# Altium schematic sheet 04_TC4xx_Supply_Clk_Cfg_Dbg.SchDoc
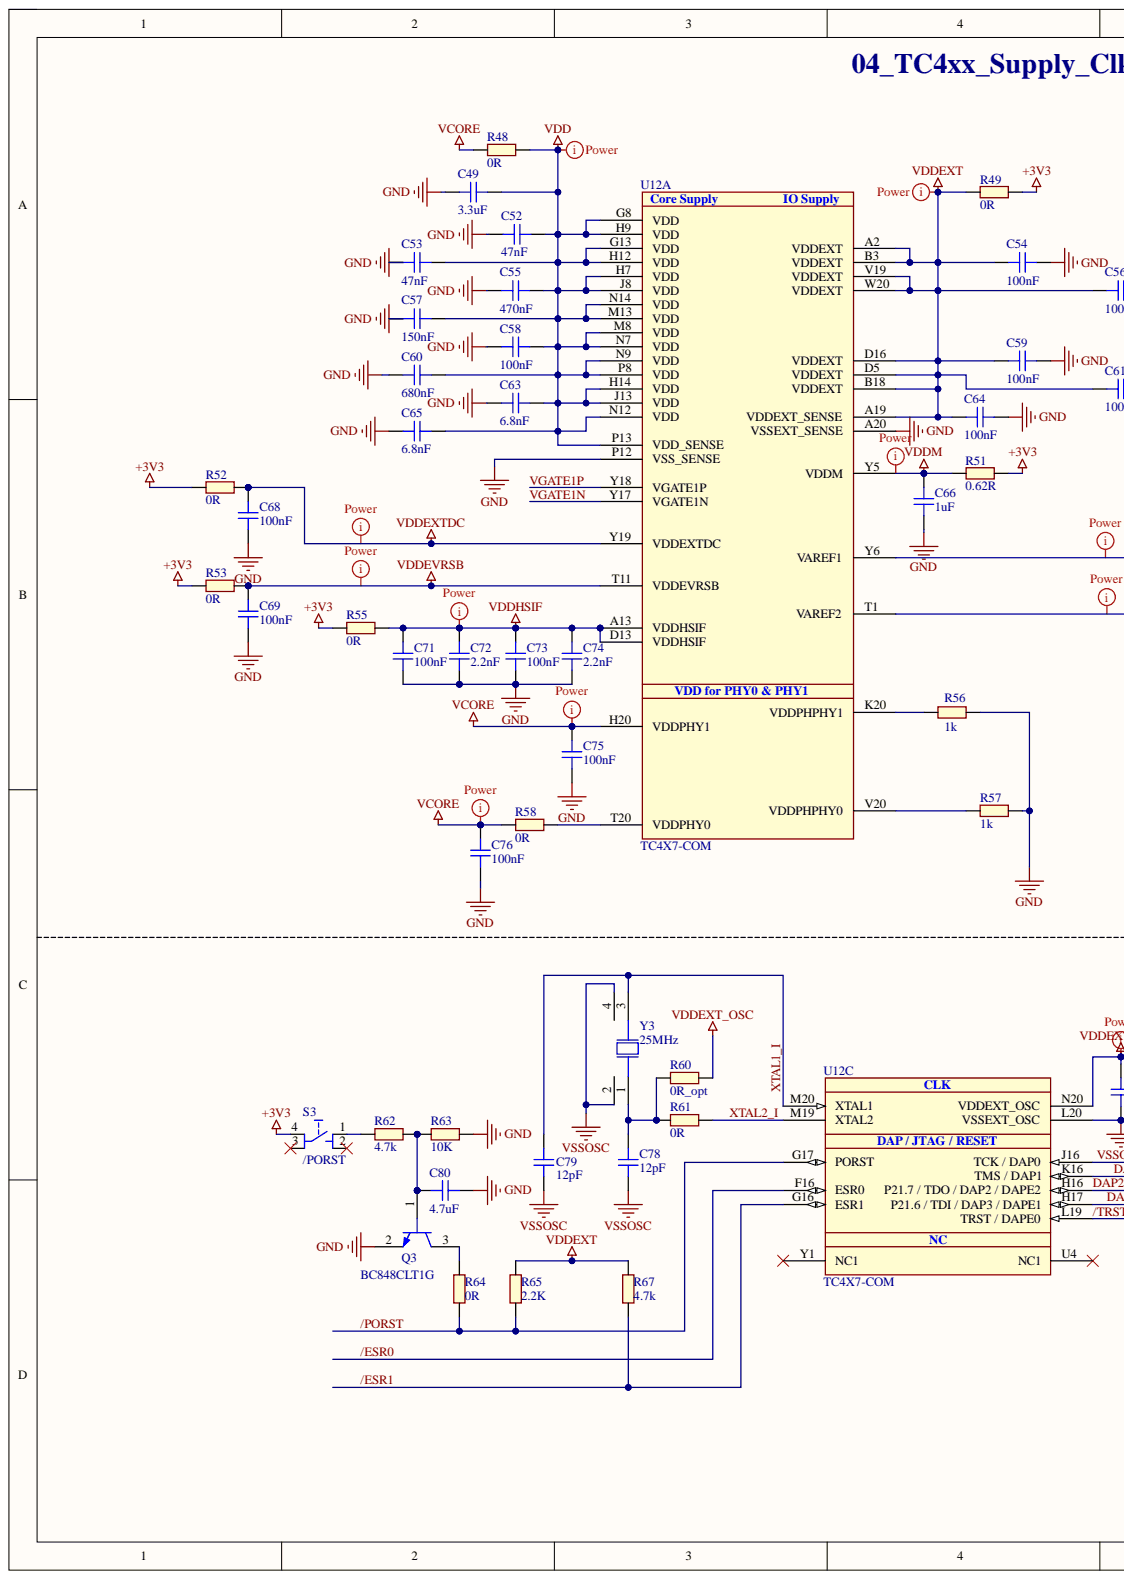
[diagram p0: 04_TC4xx_Supply_Clk_Cfg_Dbg.SchDoc, left]
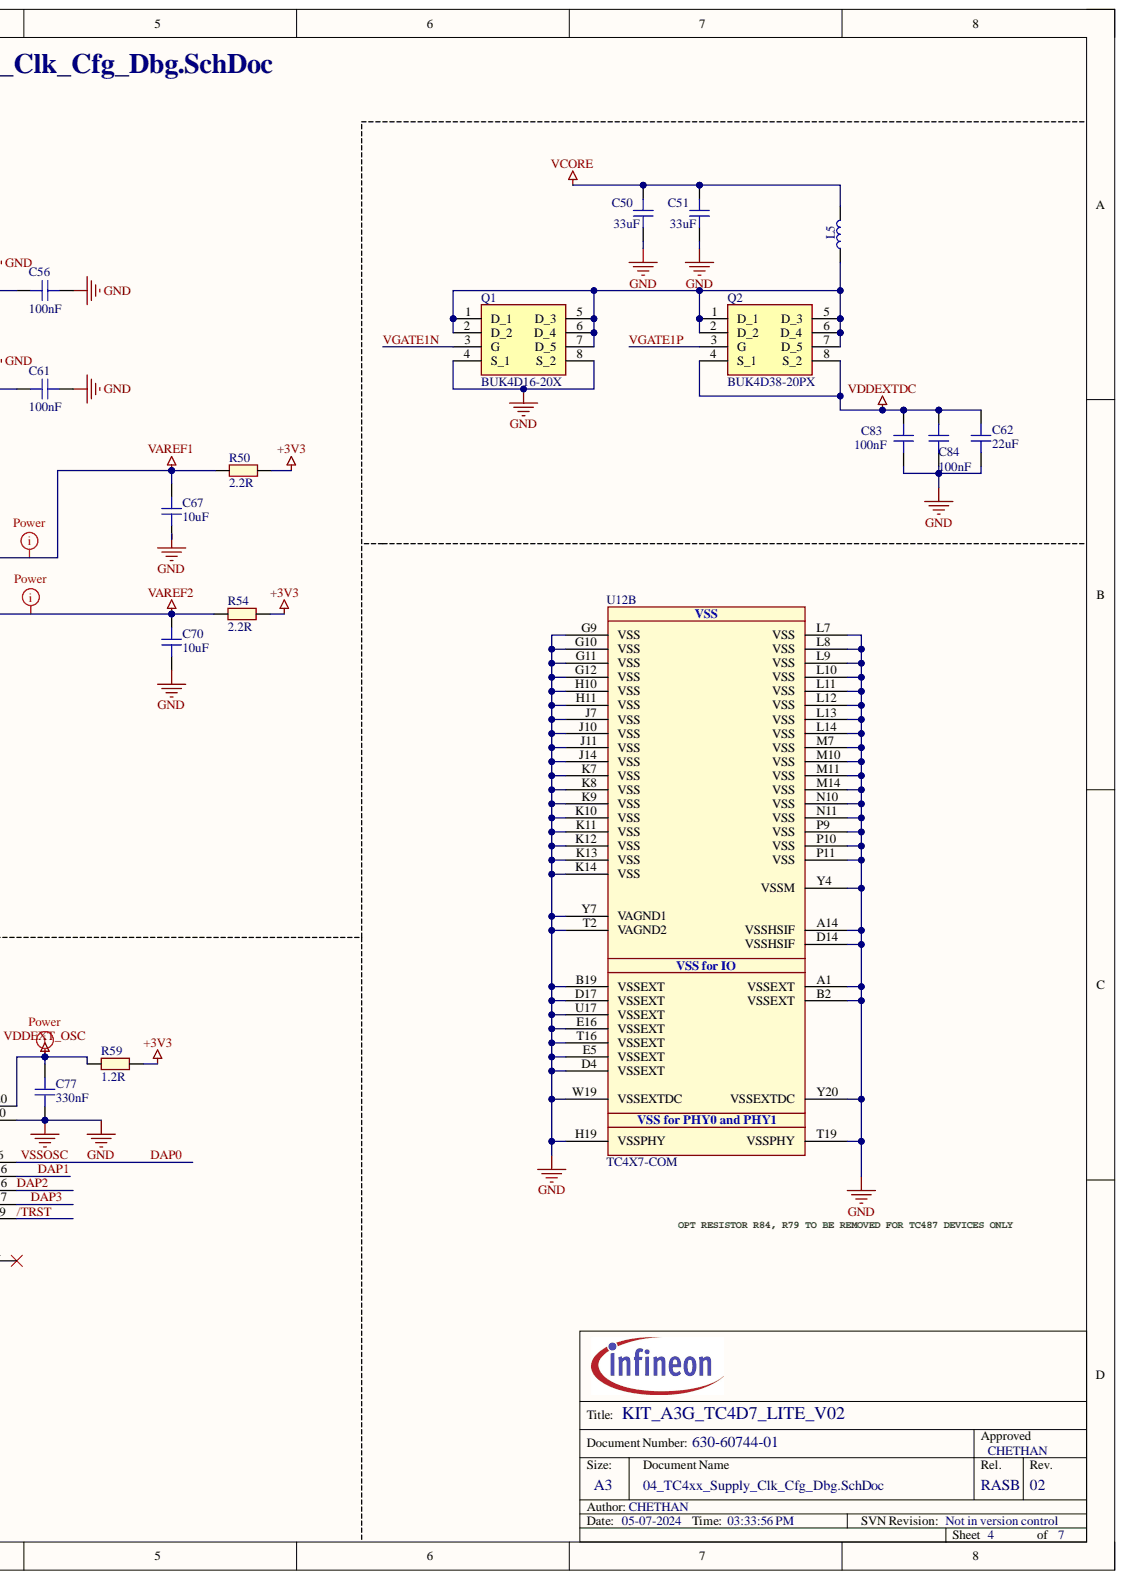
[diagram p1: 04_TC4xx_Supply_Clk_Cfg_Dbg.SchDoc, right]

## Parts
C49  3.3uF  [CAPC1608X90N-3_1]
C50  33uF  [CAPC3225X280N-3]
C51  33uF  [CAPC3225X280N-3]
C52  47nF  [CAPC1005X55N-0]
C53  47nF  [CAPC1005X55N-0]
C54  100nF  [CAPC1005X55N-0]
C55  470nF  [CAPC1005X55N-0]
C56  100nF  [CAPC1005X55N-0]
C57  150nF  [CAPC1005X55N-0]
C58  100nF  [CAPC1005X55N-0]
C59  100nF  [CAPC1005X55N-0]
C60  680nF  [CAPC1005X55N-0]
C61  100nF  [CAPC1005X55N-0]
C62  22uF  [CAPC3225X280N-0]
C63  6.8nF  [CAPC1005X55N-0]
C64  100nF  [CAPC1005X55N-0]
C65  6.8nF  [CAPC1005X55N-0]
C66  1uF  [CAPC1005X55N]
C67  10uF  [CAPC1608X100N]
C68  100nF  [CAPC1005X55N-0]
C69  100nF  [CAPC1005X55N-0]
C70  10uF  [CAPC1608X100N]
C71  100nF  [CAPC1005X55N-0]
C72  2.2nF  [CAPC1005X55N-0]
C73  100nF  [CAPC1005X55N-0]
C74  2.2nF  [CAPC1005X55N-0]
C75  100nF  [CAPC1005X55N-0]
C76  100nF  [CAPC1005X55N-0]
C77  330nF  [CAPC1005X55N-0]
C78  12pF  [CAPC1608X90N]
C79  12pF  [CAPC1608X90N]
C80  4.7uF  [CAPC1005X65N]
C83  100nF  [CAPC1005X55N-0]
C84  100nF  [CAPC1005X55N-0]
L5  XAL7030-222MEC  [XAL7030-222MEC]  (value not drawn)
Q1  BUK4D16-20X  [BUK4D1620X]
Q2  BUK4D38-20PX  [BUK4D3820PX]
Q3  BC848CLT1G  [SOT95P240X110-3N_01]
R48  0R  [RESC3246X60N]
R49  0R  [RESC3225X60N]
R50  2.2R  [RESC1609X50N]
R51  0.62R  [RESC1608X55N-2]
R52  0R  [RESC3225X60N]
R53  0R  [RESC1609X50N]
R54  2.2R  [RESC1609X50N]
R55  0R  [RESC1609X50N]
R56  1k  [RESC1005X40N-1]
R57  1k  [RESC1005X40N-1]
R58  0R  [RESC1609X50N]
R59  1.2R  [RESC1609X50N]
R60  0R_opt  [RESC1609X50N]
R61  0R  [RESC1609X50N]
R62  4.7k  [RESC1005X40N]
R63  10K  [RESC1005X40N]
R64  0R  [RESC1609X50N]
R65  2.2K  [RESC1609X50N]
R67  4.7k  [RESC1609X50N]
S3  /PORST  [FSM2JSMA]
U12  TC4X7-COM  [TC4X7_292BGA]  (parts A,B,C)
Y3  25MHz  [ECS2501233QJESTR]

## Nets
+3V3: R49.1 R50.2 R51.2 R52.2 R53.1 R54.2 R55.1 R59.2 S3.4
GND: C49.1 C50.1 C51.1 C52.1 C53.1 C54.2 C55.1 C56.2 C57.1 C58.1 C59.2 C60.1 C61.2 C62.2 C63.1 C64.2 C65.1 C66.1 C67.1 C68.1 C69.1 C70.1 C71.1 C72.2 C73.2 C74.2 C75.2 C76.2 C77.1 C78.1 C79.1 C80.1 C83.1 C84.1 Q1.4(S_1) Q1.8(S_2) Q3.2 R56.1 R57.2 R63.2 U12.A1(VSSEXT) U12.A14(VSSHSIF) U12.A20(VSSEXT_SENSE) U12.B2(VSSEXT) U12.B19(VSSEXT) U12.D4(VSSEXT) U12.D14(VSSHSIF) U12.D17(VSSEXT) U12.E5(VSSEXT) U12.E16(VSSEXT) U12.G9(VSS) U12.G10(VSS) U12.G11(VSS) U12.G12(VSS) U12.H10(VSS) U12.H11(VSS) U12.H19(VSSPHY) U12.J7(VSS) U12.J10(VSS) U12.J11(VSS) U12.J14(VSS) U12.K7(VSS) U12.K8(VSS) U12.K9(VSS) U12.K10(VSS) U12.K11(VSS) U12.K12(VSS) U12.K13(VSS) U12.K14(VSS) U12.L7(VSS) U12.L8(VSS) U12.L9(VSS) U12.L10(VSS) U12.L11(VSS) U12.L12(VSS) U12.L13(VSS) U12.L14(VSS) U12.L20(VSSEXT_OSC) U12.M7(VSS) U12.M10(VSS) U12.M11(VSS) U12.M14(VSS) U12.N10(VSS) U12.N11(VSS) U12.P9(VSS) U12.P10(VSS) U12.P11(VSS) U12.P12(VSS_SENSE) U12.T2(VAGND2) U12.T16(VSSEXT) U12.T19(VSSPHY) U12.U17(VSSEXT) U12.W19(VSSEXTDC) U12.Y4(VSSM) U12.Y7(VAGND1) U12.Y20(VSSEXTDC) Y3.2 Y3.4
VAREF1: C67.2 R50.1 U12.Y6(VAREF1)
VAREF2: C70.2 R54.1 U12.T1(VAREF2)
VCORE: C50.2 C51.2 C75.1 C76.1 L5.2 R48.1 R58.2 U12.H20(VDDPHY1)
VDD: C49.2 C52.2 C53.2 C55.2 C57.2 C58.2 C60.2 C63.2 C65.2 R48.2 U12.G8(VDD) U12.G13(VDD) U12.H7(VDD) U12.H9(VDD) U12.H12(VDD) U12.H14(VDD) U12.J8(VDD) U12.J13(VDD) U12.M8(VDD) U12.M13(VDD) U12.N7(VDD) U12.N9(VDD) U12.N12(VDD) U12.N14(VDD) U12.P8(VDD) U12.P13(VDD_SENSE)
VDDEVRSB: C69.2 R53.2 U12.T11(VDDEVRSB)
VDDEXT: C54.1 C56.1 C59.1 C61.1 C64.1 R49.2 R65.2 R67.2 U12.A2(VDDEXT) U12.A19(VDDEXT_SENSE) U12.B3(VDDEXT) U12.B18(VDDEXT) U12.D5(VDDEXT) U12.D16(VDDEXT) U12.V19(VDDEXT) U12.W20(VDDEXT)
VDDEXT_OSC: C77.2 R59.1 R60.2 U12.N20(VDDEXT_OSC)
VDDEXTDC: C62.1 C68.2 C83.2 C84.2 Q2.4(S_1) Q2.8(S_2) R52.1 U12.Y19(VDDEXTDC)
VDDHSIF: C71.2 C72.1 C73.1 C74.1 R55.2 U12.A13(VDDHSIF) U12.D13(VDDHSIF)
VDDM: C66.2 R51.1 U12.Y5(VDDM)
/ESR0: U12.F16(ESR0)
/ESR1: R67.1 U12.G16(ESR1)
/PORST: R64.1 R65.1 U12.G17(PORST)
/TRST: U12.L19(TRST_/_DAPE0)
DAP0: U12.J16(TCK_/_DAP0)
DAP1: U12.K16(TMS_/_DAP1)
DAP2: U12.H16
DAP3: U12.H17
VGATE1N: Q1.3(G) U12.Y17(VGATE1N)
VGATE1P: Q2.3(G) U12.Y18(VGATE1P)
XTAL1_I: C79.2 U12.M20(XTAL1) Y3.3
XTAL2_I: R61.2 U12.M19(XTAL2)
NetC78_2: C78.2 R60.1 R61.1 Y3.1
NetC80_2: C80.2 Q3.1 R62.2 R63.1
NetL5_1: L5.1 Q1.1(D_1) Q1.2(D_2) Q1.5(D_3) Q1.6(D_4) Q1.7(D_5) Q2.1(D_1) Q2.2(D_2) Q2.5(D_3) Q2.6(D_4) Q2.7(D_5)
NetQ3_3: Q3.3 R64.2
NetR56_2: R56.2 U12.K20(VDDPHPHY1)
NetR57_1: R57.1 U12.V20(VDDPHPHY0)
NetR58_1: R58.1 U12.T20(VDDPHY0)
NetR62_1: R62.1 S3.1

Not connected: S3 2 3; U12 U4(NC1) Y1(NC1)

## Library data of the parts
C49 = 626196_TB: 3.3 µF ±10% 6.3V Ceramic Capacitor X7S 0603 (1608 Metric); Manufacturer: TDK Corporation; Manufacturer Order Number: C1608X7S0J335K080AC; Multiple Parts: No; Alternate View: Yes; Automotive Qualified: AEC Q200; Combined Parameters: TC:X7S (EIA);Tol.:-10%/+10%;Vdc:6.3V; ComponentStatus: Released; PLMStatus: Active; QuickPick: 3.3uF / 6.3V / 10% / X7S (EIA) / 0603 (1608); Style: Single; Symbol Type: Capacitor; Single; Standard; 2 Pins; Type: Standard; Version Number: V01; Application: Standard; Article Number: 626196_TB; Component Kind: Standard; ComponentLink1Description: Vendor WebSite; ComponentLink1URL: http://www.murata.com/; ComponentLink2Description: Datasheet; Library Name: TriBoard TC4X7 COM.SCHLIB; Package Description: Capacitor,non-polarized,Chip;1.60mm L X 0.80mm W X 0.90mm H; PackageReference: 0603 (1608); Part Type: Capacitor; ROHS Compliant: Y; Second Source allowed: Yes; Supplier 1: Farnell; Supplier 2: DigiKey; Supplier 3: Mouser; Supplier Part Number 2: 445-14250-1-ND; Supplier Part Number 3: 810-C1608X7S0J335K0C; Technology: Ceramic; Temperature max: 125; Temperature min: -55; Temperature Range: Military; Tolerance Neg: 10%; Tolerance Pos: 10%; Value: 3.3uF; Voltage DC (max): 6.3V
C50 C51 = 308865: 33 µF ±20% 10V Ceramic Capacitor X7S 1210 (3225 Metric); Manufacturer: TDK; Manufacturer Component Status: Active; Manufacturer Order Number: CGA6M1X7S1A336M200AC; Alternate View: Yes; Automotive Qualified: No; Legacy DeviceID: CAP01010692; Package Imperial: 1210; Package Metric: 3225; QuickPick: CAP CER 33UF 10V X7S 1210; Style: Single; Symbol Type: Capacitor; Single; Standard; 2 Pins; Type: Standard; Version Number: V01; Voltage DC (max): 16V; Article Number: 308865; Combined Parameters: 33 µF ±20% 10V Ceramic Capacitor X7S 1210 (3225 Metric); Component Kind: Standard; ComponentLink1Description: Vendor WebSite; ComponentLink2Description: Datasheet; Legacy PartNumber: CAP01010692; Multiple Parts: No; Package Description: Capacitor,non-polarized,Chip;3.20mm L X 2.50mm W X 2.80mm H; PackageReference: 1210; Part Type: Capacitor; PLMStatus: Active; ROHS Compliant: Y; Second Source allowed: Yes; Supplier 1: Farnell; Supplier 2: DigiKey; Supplier 3: Mouser; Supplier Part Number 2: 445-CGA6M1X7S1A336M200ACCT-ND; Supplier Part Number 3: 810-CGA6M1X7S1A336M2; Technology: Ceramic; Temperature max: 85; Temperature min: -55; Temperature Range: Military; Tolerance Neg: 20%; Tolerance Pos: 20%; Value: 33uF; Library Name: AURIX_Lite_Kit_V2.0.SCHLIB
  C50: ComponentLink2URL: https://product.tdk.com/system/files/dam/doc/product/capacitor/ceramic/mlcc/catalog/mlcc_commercial_general_en.pdf
  C51: ComponentLink1URL: http://www.kemet.com; ComponentLink2URL: http://webnetprod.muc.infineon.com/PCBPortal_Prod/WorkFlows/AltiumComponent/StandardDocumentation?Versionid=308866
C52 C53 = 287081: 0.047 µF ±10% 25V Ceramic Capacitor X7R 0402 (1005 Metric); Manufacturer: MuRata; Manufacturer Order Number: GCM155R71E473KA55D; Multiple Parts: No; Alternate View: Yes; Automotive Qualified: AEC Q200; Combined Parameters: TC:X7R (EIA);Tol.:-10%/+10%;Vdc:25V; ComponentStatus: Released; Legacy DeviceID: CAP01006489; Package Imperial: 0402; Package Metric: 1005; PLMStatus: Active; QuickPick: 47nF / 25V / 10% / X7R (EIA) / CAPC1005X55N-0; Style: Single; Symbol Type: Capacitor; Single; Standard; 2 Pins; Type: Standard; Version Number: V01; Application: Standard; Article Number: 287081; Component Kind: Standard; ComponentLink1Description: Vendor WebSite; ComponentLink1URL: http://www.murata.com/; ComponentLink2Description: Datasheet; ComponentLink2URL: http://webnetprod.muc.infineon.com/PCBPortal_Prod/WorkFlows/AltiumComponent/StandardDocumentation?Versionid=287082; Legacy PartNumber: CAP01006489; Library Name: TriBoard_TC4X7_COM_V20.SCHLIB; Package Description: Capacitor,non-polarized,Chip;1.00mm L X 0.50mm W X 0.55mm H; PackageReference: CAPC1005X55N-0; Part Type: Capacitor; ROHS Compliant: Y; Second Source allowed: Yes; Supplier 1: Farnell; Supplier 2: DigiKey; Supplier 3: Mouser; Supplier Part Number 2: 490-4760-1-ND; Technology: Ceramic; Temperature max: 125; Temperature min: -55; Temperature Range: Military; Tolerance Neg: 10%; Tolerance Pos: 10%; Value: 47nF; Voltage DC (max): 25V
C54 C56 C59 C61 C64 C71 C83 C84 = 287096: 0.1 µF ±10% 50V Ceramic Capacitor X7R 0402 (1005 Metric); Manufacturer: MuRata; Manufacturer Order Number: GCM155R71H104KE02J; Multiple Parts: No; Alternate View: Yes; Automotive Qualified: AEC Q200; Combined Parameters: TC:X7R (EIA);Tol.:-10%/+10%;Vdc:50V; Legacy DeviceID: CAP01006492; Package Imperial: 0402; Package Metric: 1005; PLMStatus: Active; QuickPick: 100nF / 50V / 10% / X7R (EIA) / CAPC1005X55N-0; Style: Single; Symbol Type: Capacitor; Single; Standard; 2 Pins; Type: Standard; Version Number: V01; Application: Standard; Article Number: 287096; Component Kind: Standard; ComponentLink1Description: Vendor WebSite; ComponentLink1URL: http://www.murata.com/; ComponentLink2Description: Datasheet; ComponentLink2URL: http://webnetprod.muc.infineon.com/PCBPortal_Prod/WorkFlows/AltiumComponent/StandardDocumentation?Versionid=287097; Legacy PartNumber: CAP01006492; Library Name: TriBoard_TC4X7_COM_V20.SCHLIB; Package Description: Capacitor,non-polarized,Chip;1.00mm L X 0.50mm W X 0.55mm H; PackageReference: CAPC1005X55N-0; Part Type: Capacitor; ROHS Compliant: Y; Second Source allowed: Yes; Supplier 1: Farnell; Supplier 2: DigiKey; Supplier 3: Mouser; Supplier Part Number 2: 490-14514-1-ND; Technology: Ceramic; Temperature max: 125; Temperature min: -55; Temperature Range: Military; Tolerance Neg: 10%; Tolerance Pos: 10%; Value: 100nF; Voltage DC (max): 50V
C55 = 630884: 0.47 µF ±10% 10V Ceramic Capacitor X7R 0402 (1005 Metric); Manufacturer: MuRata; Manufacturer Order Number: GRT155R71A474KE01D; Multiple Parts: No; Alternate View: Yes; Automotive Qualified: AEC Q200; Combined Parameters: TC:X7R (EIA);Tol.:-10%/+10%;Vdc:10V; ComponentStatus: Released; PLMStatus: Active; QuickPick: 470nF / 10V / 10% / X7R (EIA) / 1005(0402); Style: Single; Symbol Type: Capacitor; Single; Standard; 2 Pins; Type: Standard; Version Number: V01; Application: Standard; Article Number: 630884; Component Kind: Standard; ComponentLink1Description: Vendor WebSite; ComponentLink1URL: http://www.murata.com/; ComponentLink2Description: Datasheet; ComponentLink2URL: http://webnetprod.muc.infineon.com/PCBPortal_Prod/WorkFlows/AltiumComponent/StandardDocumentation?Versionid=630885; Library Name: TriBoard_TC4X7_COM_V20.SCHLIB; Package Description: Capacitor,non-polarized,Chip;1.00mm L X 0.50mm W X 0.55mm H; PackageReference: 1005(0402); Part Type: Capacitor; ROHS Compliant: Y; Second Source allowed: Yes; Supplier 1: Farnell; Supplier 2: DigiKey; Supplier 3: Mouser; Supplier Part Number 1: 3581434; Supplier Part Number 2: 490-GRT155R71A474KE01DCT-ND; Supplier Part Number 3: 81-GRT155R71A474KE1D; Technology: Ceramic; Temperature max: 125; Temperature min: -55; Temperature Range: Military; Tolerance Neg: 10%; Tolerance Pos: 10%; Value: 470nF; Voltage DC (max): 10V
C57 = 488142_TB: 0.15 µF ±10% 16V Ceramic Capacitor X7R 0402 (1005 Metric); Manufacturer: MuRata; Manufacturer Order Number: GCM155R71C154KE02D; Multiple Parts: No; Alternate View: Yes; Automotive Qualified: AEC Q200; Combined Parameters: TC:X7R (EIA);Tol.:-10%/+10%;Vdc:16V; ComponentStatus: Released; Package Imperial: 0402; Package Metric: 1005; PLMStatus: Active; QuickPick: 150nF / 16V / 10% / X7R (EIA) / 0402; Style: Single; Symbol Type: Capacitor; Single; Standard; 2 Pins; Type: Standard; Version Number: V01; Application: Standard; Article Number: 488142_TB; Component Kind: Standard; ComponentLink1Description: Vendor WebSite; ComponentLink1URL: http://www.murata.com/; ComponentLink2Description: Datasheet; Library Name: TriBoard_TC4X7_COM_V20.SCHLIB; Package Description: Capacitor,non-polarized,Chip;1.00mm L X 0.50mm W X 0.55mm H; PackageReference: 0402; Part Type: Capacitor; ROHS Compliant: Y; Second Source allowed: Yes; Supplier 1: Farnell; Supplier 2: DigiKey; Supplier 3: Mouser; Supplier Part Number 1: 3582683; Supplier Part Number 2: 490-GCM155R71C154KE02DCT-ND; Supplier Part Number 3: 81-GCM155R71C154KE2D; Technology: Ceramic; Temperature max: 125; Temperature min: -55; Temperature Range: Military; Tolerance Neg: 10%; Tolerance Pos: 10%; Value: 150nF; Voltage DC (max): 16V
C58 C68 C69 C73 C75 C76 = 287096: 0.1 µF ±10% 50V Ceramic Capacitor X7R 0402 (1005 Metric); Manufacturer: MuRata; Manufacturer Order Number: GCM155R71H104KE02J; Multiple Parts: No; Alternate View: Yes; Automotive Qualified: AEC Q200; Combined Parameters: TC:X7R (EIA);Tol.:-10%/+10%;Vdc:50V; Database Table Name: TPartsAll; Legacy DeviceID: CAP01006492; Package Imperial: 0402; Package Metric: 1005; PLMStatus: Active; QuickPick: 100nF / 50V / 10% / X7R (EIA) / CAPC1005X55N-0; Style: Single; Symbol Type: Capacitor; Single; Standard; 2 Pins; Type: Standard; Version Number: V01; Application: Standard; Article Number: 287096; Component Kind: Standard; ComponentLink1Description: Vendor WebSite; ComponentLink1URL: http://www.murata.com/; ComponentLink2Description: Datasheet; ComponentLink2URL: http://webnetprod.muc.infineon.com/PCBPortal_Prod/WorkFlows/AltiumComponent/StandardDocumentation?Versionid=287097; Legacy PartNumber: CAP01006492; Library Name: PCBLibraryData.SVNDbLib; Package Description: Capacitor,non-polarized,Chip;1.00mm L X 0.50mm W X 0.55mm H; PackageReference: CAPC1005X55N-0; Part Type: Capacitor; ROHS Compliant: Y; Second Source allowed: Yes; Supplier 1: Farnell; Supplier 2: DigiKey; Supplier 3: Mouser; Supplier Part Number 2: 490-14514-1-ND; Technology: Ceramic; Temperature max: 125; Temperature min: -55; Temperature Range: Military; Tolerance Neg: 10%; Tolerance Pos: 10%; Value: 100nF; Voltage DC (max): 50V
C60 = 612568_TB: 0.68 µF ±10% 10V Ceramic Capacitor X7S 0402 (1005 Metric); Manufacturer: MuRata; Manufacturer Order Number: GCM155C71A684KE38D; Multiple Parts: No; Alternate View: Yes; Automotive Qualified: AEC Q200; Combined Parameters: TC:X7S (EIA);Tol.:-10%/+10%;Vdc:10V; ComponentStatus: Released; PLMStatus: Active; QuickPick: 680nF / 10V / 10% / X7S (EIA) / 0402 (1005); Style: Single; Symbol Type: Capacitor; Single; Standard; 2 Pins; Type: Standard; Version Number: V01; Application: Standard; Article Number: 612568_TB; Component Kind: Standard; ComponentLink1Description: Vendor WebSite; ComponentLink1URL: http://www.murata.com/; ComponentLink2Description: Datasheet; Library Name: TriBoard_TC4X7_COM_V20.SCHLIB; Package Description: Capacitor,non-polarized,Chip;1.00mm L X 0.50mm W X 0.55mm H; PackageReference: 0402 (1005); Part Type: Capacitor; ROHS Compliant: Y; Second Source allowed: Yes; Supplier 1: Farnell; Supplier 2: DigiKey; Supplier 3: Mouser; Supplier Part Number 2: 490-GCM155C71A684KE38DCT-ND; Supplier Part Number 3: 81-GCM155C71A684KE8D; Technology: Ceramic; Temperature max: 125; Temperature min: -55; Temperature Range: Military; Tolerance Neg: 10%; Tolerance Pos: 10%; Value: 680nF; Voltage DC (max): 10V
C62 = CAP-SGL-STD-02P_00000001: 22 µF ±10% 16V Ceramic Capacitor X7R 1210 (3225 Metric); Manufacturer: Samsung Electro-Mechanics; Manufacturer Order Number: CL32B226KOJVPNE; Multiple Parts: No; Alternate View: Yes; Symbol Type: Capacitor; Single; Standard; 2 Pins; Component Kind: Standard; Library Name: CAP-SGL-STD-02P_00000001.SchLib; Supplier Part Number 2: 1276-6817-1-ND; Supplier Part Number 3: 187-CL32B226KOJVPNE; Value: 22uF
C63 C65 = 289241: 6800 pF ±10% 25V Ceramic Capacitor X7R 0402 (1005 Metric); Manufacturer: MuRata; Manufacturer Order Number: GCM155R71E682KA37D; Multiple Parts: No; Alternate View: Yes; Automotive Qualified: AEC Q200; Combined Parameters: TC:X7R (EIA);Tol.:-10%/+10%;Vdc:25V; ComponentStatus: Released; Legacy DeviceID: CAP01006921; Package Imperial: 0402; Package Metric: 1005; PLMStatus: Active; QuickPick: 6.8nF / 25V / 10% / X7R (EIA) / CAPC1005X55N-0; Style: Single; Symbol Type: Capacitor; Single; Standard; 2 Pins; Type: Standard; Version Number: V01; Application: Standard; Article Number: 289241; Component Kind: Standard; ComponentLink1Description: Vendor WebSite; ComponentLink1URL: http://www.murata.com/; ComponentLink2Description: Datasheet; ComponentLink2URL: http://webnetprod.muc.infineon.com/PCBPortal_Prod/WorkFlows/AltiumComponent/StandardDocumentation?Versionid=289242; Legacy PartNumber: CAP01006921; Library Name: TriBoard_TC4X7_COM_V20.SCHLIB; Package Description: Capacitor,non-polarized,Chip;1.00mm L X 0.50mm W X 0.55mm H; PackageReference: CAPC1005X55N-0; Part Type: Capacitor; ROHS Compliant: Y; Second Source allowed: Yes; Supplier 1: Farnell; Supplier 2: DigiKey; Supplier 3: Mouser; Supplier Part Number 2: 490-17758-1-ND; Technology: Ceramic; Temperature max: 125; Temperature min: -55; Temperature Range: Military; Tolerance Neg: 10%; Tolerance Pos: 10%; Value: 6.8nF; Voltage DC (max): 25V
C66 = 309460: 1 µF ±10% 10V Ceramic Capacitor X5R 0402 (1005 Metric); Manufacturer: Kemet; Manufacturer Order Number: C0402C105K8PACTU; Alternate View: Yes; Automotive Qualified: No; Combined Parameters: TC:X5R (EIA);Tol.:-10%/+10%;Vdc:10V; ComponentStatus: Released; Legacy DeviceID: CAP01010765; Package Imperial: 0402; Package Metric: 1005; PLMStatus: Active; QuickPick: 1uF / 10V / 10% / X5R (EIA) / 0402 (1005); Style: Single; Symbol Type: Capacitor; Single; Standard; 2 Pins; Type: Standard; Version Number: V01; Article Number: 309460; Component Kind: Standard; ComponentLink1Description: Vendor WebSite; ComponentLink1URL: http://www.kemet.com; ComponentLink2Description: Datasheet; ComponentLink2URL: http://webnetprod.muc.infineon.com/PCBPortal_Prod/WorkFlows/AltiumComponent/StandardDocumentation?Versionid=309461; Legacy PartNumber: CAP01010765; Library Name: TriBoard_TC4X7_COM_V20.SCHLIB; Multiple Parts: No; Package Description: Capacitor,non-polarized,Chip;1.00mm L X 0.50mm W X 0.55mm H; PackageReference: 0402 (1005); Part Type: Capacitor; ROHS Compliant: Y; Second Source allowed: Yes; Supplier 1: Farnell; Supplier 2: DigiKey; Supplier 3: Mouser; Supplier Part Number 1: 2392237; Supplier Part Number 2: 399-C0402C105K8PAC7867CT-ND; Supplier Part Number 3: 80-C0402C105K8P; Technology: Ceramic; Temperature max: 85; Temperature min: -55; Temperature Range: Military; Tolerance Neg: 10%; Tolerance Pos: 10%; Value: 1uF; Voltage DC (max): 10V
C67 C70 = 489280: 10 µF ±20% 10V Ceramic Capacitor X7T 0603 (1608 Metric); Manufacturer: MuRata; Manufacturer Order Number: GRM188D71A106MA73D; Alternate View: Yes; Automotive Qualified: No; Combined Parameters: TC:X7T (EIA);Tol.:-20%/+20%;Vdc:10V; ComponentStatus: Released; Database Table Name: TPartsAll; Package Imperial: 0603; Package Metric: 1608; PLMStatus: Active; QuickPick: 10uF / 10V / 20% / X7T (EIA) / 0603; Style: Single; Symbol Type: Capacitor; Single; Standard; 2 Pins; Type: Standard; Version Number: V01; Application: Standard; Article Number: 489280; Component Kind: Standard; ComponentLink1Description: Vendor WebSite; ComponentLink1URL: http://www.murata.com/; ComponentLink2Description: Datasheet; ComponentLink2URL: http://webnetprod.muc.infineon.com/PCBPortal_Prod/WorkFlows/AltiumComponent/StandardDocumentation?Versionid=489281; Library Name: PCBLibraryData.SVNDbLib; Multiple Parts: No; Package Description: Capacitor,non-polarized,Chip;1.60mm L X 0.80mm W X 1.00mm H; PackageReference: 0603; Part Type: Capacitor; ROHS Compliant: Y; Second Source allowed: Yes; Supplier 1: Farnell; Supplier 2: DigiKey; Supplier 3: Mouser; Supplier Part Number 1: 2611920RL; Supplier Part Number 2: 490-7200-1-ND; Supplier Part Number 3: 81-GRM188D71A106MA3D; Technology: Ceramic; Temperature max: 125; Temperature min: -55; Temperature Range: Military; Tolerance Neg: 20%; Tolerance Pos: 20%; Value: 10uF; Voltage DC (max): 10V
C72 C74 = 265423: 2200 pF ±10% 50V Ceramic Capacitor X7R 0402 (1005 Metric); Manufacturer: MuRata; Manufacturer Order Number: GRM155R71H222KA01D; Alternate View: Yes; Automotive Qualified: No; Combined Parameters: TC:X7R (EIA);Tol.:-10%/+10%;Vdc:50V; ComponentStatus: Released; Database Table Name: TPartsAll; Legacy DeviceID: CAP01001878; Package Imperial: 0402; Package Metric: 1005; PLMStatus: Active; QuickPick: 2.2nF / 50V / 10% / X7R (EIA) / CAPC1005X55N-0; Style: Single; Symbol Type: Capacitor; Single; Standard; 2 Pins; Type: Standard; Version Number: V01; Application: Standard; Article Number: 265423; Component Kind: Standard; ComponentLink1Description: Vendor WebSite; ComponentLink1URL: http://www.murata.com/; ComponentLink2Description: Datasheet; ComponentLink2URL: http://webnetprod.muc.infineon.com/PCBPortal_Prod/WorkFlows/AltiumComponent/StandardDocumentation?Versionid=265424; Legacy PartNumber: CAP01001878; Library Name: PCBLibraryData.SVNDbLib; Multiple Parts: No; Package Description: Capacitor,non-polarized,Chip;1.00mm L X 0.50mm W X 0.55mm H; PackageReference: CAPC1005X55N-0; Part Type: Capacitor; ROHS Compliant: Y; Second Source allowed: Yes; Supplier 1: Farnell; Supplier 2: DigiKey; Supplier 3: Mouser; Supplier Part Number 2: 490-1305-1-ND; Technology: Ceramic; Temperature max: 125; Temperature min: -55; Temperature Range: Military; Tolerance Neg: 10%; Tolerance Pos: 10%; Value: 2.2nF; Voltage DC (max): 50V
C77 = 263919: 0.33 µF ±10% 10V Ceramic Capacitor X5R 0402 (1005 Metric); Manufacturer: MuRata; Manufacturer Order Number: GRM155R61A334KE15D; Alternate View: Yes; Automotive Qualified: No; Combined Parameters: TC:X5R (EIA);Tol.:-10%/+10%;Vdc:10V; Database Table Name: TPartsAll; Legacy DeviceID: CAP01001576; Package Imperial: 0402; Package Metric: 1005; PLMStatus: Not Recommended For New Designs; QuickPick: 330nF / 10V / 10% / X5R (EIA) / CAPC1005X55N-0; Style: Single; Symbol Type: Capacitor; Single; Standard; 2 Pins; Type: Standard; Version Number: V01; Application: Standard; Article Number: 263919; Component Kind: Standard; ComponentLink1Description: Vendor WebSite; ComponentLink1URL: http://www.murata.com/; ComponentLink2Description: Datasheet; ComponentLink2URL: http://webnetprod.muc.infineon.com/PCBPortal_Prod/WorkFlows/AltiumComponent/StandardDocumentation?Versionid=263920; Legacy PartNumber: CAP01001576; Library Name: PCBLibraryData.SVNDbLib; Multiple Parts: No; Package Description: Capacitor,non-polarized,Chip;1.00mm L X 0.50mm W X 0.55mm H; PackageReference: CAPC1005X55N-0; Part Type: Capacitor; ROHS Compliant: Y; Second Source allowed: Yes; Supplier 1: Farnell; Supplier 2: DigiKey; Supplier 3: Mouser; Supplier Part Number 2: 490-3263-1-ND; Technology: Ceramic; Temperature max: 85; Temperature min: -55; Temperature Range: Military; Tolerance Neg: 10%; Tolerance Pos: 10%; Value: 330nF; Voltage DC (max): 10V
C78 C79 = 267353: 12 pF ±2% 50V Ceramic Capacitor C0G, NP0 0603 (1608 Metric); Manufacturer: MuRata; Manufacturer Order Number: GRM1885C1H120GA01D; Alternate View: Yes; Automotive Qualified: No; Combined Parameters: TC:C0G (EIA) / NP0;Tol.:-2%/+2%;Vdc:50V; ComponentStatus: Released; Database Table Name: TPartsAll; Legacy DeviceID: CAP01002477; Package Imperial: 0603; Package Metric: 1608; PLMStatus: Not Recommended For New Designs; QuickPick: 12pF / 50V / 2% / C0G (EIA) / NP0 / 0603 (1608); Style: Single; Symbol Type: Capacitor; Single; Standard; 2 Pins; Type: Standard; Version Number: V01; Application: Standard; Article Number: 267353; Component Kind: Standard; ComponentLink1Description: Vendor WebSite; ComponentLink1URL: http://www.murata.com/; ComponentLink2Description: Datasheet; ComponentLink2URL: http://webnetprod.muc.infineon.com/PCBPortal_Prod/WorkFlows/AltiumComponent/StandardDocumentation?Versionid=267354; Legacy PartNumber: CAP01002477; Library Name: PCBLibraryData.SVNDbLib; Multiple Parts: No; Package Description: Capacitor,non-polarized,Chip;1.60mm L X 0.80mm W X 0.90mm H; PackageReference: 0603 (1608); Part Type: Capacitor; ROHS Compliant: Y; Second Source allowed: Yes; Supplier 1: Farnell; Supplier 2: DigiKey; Supplier 3: Mouser; Supplier Part Number 2: 490-11436-1-ND; Technology: Ceramic; Temperature max: 125; Temperature min: -55; Temperature Range: Military; Tolerance Neg: 2%; Tolerance Pos: 2%; Value: 12pF; Voltage DC (max): 50V
C80 = 310675: 4.7 µF ±10% 10V Ceramic Capacitor X5R 0402 (1005 Metric); Manufacturer: TDK Corporation; Manufacturer Order Number: C1005X5R1A475K050BC; Alternate View: Yes; Automotive Qualified: No; Combined Parameters: TC:X5R (EIA);Tol.:-10%/+10%;Vdc:10V; Database Table Name: TPartsAll; Legacy DeviceID: CAP01010928; PLMStatus: Active; QuickPick: 4.7uF / 10V / 10% / X5R (EIA) / 0402 (1005); Style: Single; Symbol Type: Capacitor; Single; Standard; 2 Pins; Type: Standard; Version Number: V01; Article Number: 310675; Component Kind: Standard; ComponentLink1Description: Vendor WebSite; ComponentLink1URL: http://www.tdk.com; ComponentLink2Description: Datasheet; ComponentLink2URL: http://webnetprod.muc.infineon.com/PCBPortal_Prod/WorkFlows/AltiumComponent/StandardDocumentation?Versionid=310676; Legacy PartNumber: CAP01010928; Library Name: PCBLibraryData.SVNDbLib; Multiple Parts: No; Package Description: Capacitor,non-polarized,Chip;1.025mm L X 0.525mm W X 0.65mm H; PackageReference: 0402 (1005); Part Type: Capacitor; ROHS Compliant: Y; Second Source allowed: Yes; Supplier 1: Farnell; Supplier 2: DigiKey; Supplier 3: Mouser; Supplier Part Number 1: 2528756RL; Supplier Part Number 2: 445-13820-1-ND; Supplier Part Number 3: 810-C1005X5R1A475KBC; Technology: Ceramic; Temperature max: 85; Temperature min: -55; Temperature Range: Military; Tolerance Neg: 10%; Tolerance Pos: 10%; Value: 4.7uF; Voltage DC (max): 10V
L5 = 326302: Power Inductors - SMD 2.2uH Shld 20% 12.9A 15.07mOhms AECQ2; Manufacturer: Coilcraft; Alternate View: Yes; Automotive Qualified: No; PLMStatus: Active; QuickPick: 2.2uH / SMD; Symbol Type: Inductor; Single; Standard; 2 Pins; Tolerance Neg: 30%; Version Number: V01; Voltage DC (max): na; Combined Parameters: SMD 2.2uH Shld 20% 12.9A 15.07mOhms AECQ2; Component Kind: Standard; ComponentLink1Description: Vendor WebSite; ComponentLink2Description: Datasheet; ComponentLink2URL: https://www.mouser.in/datasheet/2/597/xal7030-270749.pdf; Manufacturer Order Number: XAL7030-222MEC; Multiple Parts: No; Package Description: Inductor,Molded Body; 5mm L X 5.2mm W X 2.2mm H; PackageReference: SMD; Part Type: Inductor; Second Source allowed: Yes; Supplier 1: Mouser; Supplier Part Number 1: 994-XAL7030-222MEC; Supplier Part Number 2: 2457-XAL7030-222MEC-ND; Supplier Part Number 3: 994-XAL7030-222MEC; Temperature Range: Military; Tolerance Pos: 30%; Value: 2.2uH; Library Name: AURIX_Lite_Kit_V2.0.SCHLIB
Q1 = BUK4D16-20X: N-Channel 20 V 8.5A (Ta), 26A (Tc) 2W (Ta), 19W (Tc) Surface Mount DFN2020MD-6; Manufacturer: Nexperia USA Inc.; Manufacturer_Name: Nexperia; Geometry.Height: 0.65mm; Component Kind: Standard; Datasheet Link: https://assets.nexperia.com/documents/data-sheet/BUK4D16-20.pdf; Library Name: BUK4D16-20X.SchLib; Manufacturer Order Number: BUK4D16-20X; Manufacturer_Part_Number: BUK4D16-20X; Mouser Part Number: 771-BUK4D16-20X; Mouser Price/Stock: https://www.mouser.co.uk/ProductDetail/Nexperia/BUK4D16-20X?qs=W%2FMpXkg%252BdQ6mXCXkgBkZlA%3D%3D; Supplier Part Number 2: 1727-BUK4D16-20XTR-ND
Q2 = BUK4D38-20PX: P-Channel 20 V 6A (Ta), 18A (Tc) 2W (Ta), 19W (Tc) Surface Mount DFN2020MD-6; Manufacturer: Nexperia USA Inc.; Manufacturer_Name: Nexperia; Geometry.Height: 0.65mm; Component Kind: Standard; Datasheet Link: https://www.mouser.com/datasheet/2/916/BUK4D38-20P-1880069.pdf; Library Name: BUK4D38-20PX.SchLib; Manufacturer Order Number: BUK4D38-20PX; Manufacturer_Part_Number: BUK4D38-20PX; Mouser Part Number: 771-BUK4D38-20PX; Mouser Price/Stock: https://www.mouser.co.uk/ProductDetail/Nexperia/BUK4D38-20PX?qs=W%2FMpXkg%252BdQ5s0QIDI%252Bezeg%3D%3D; Supplier Part Number 2: 1727-BUK4D38-20PXTR-ND; Supplier Part Number 3: 771-BUK4D38-20PX
Q3 = 421477: Bipolar (BJT) Transistor NPN 30 V 100 mA 100MHz 300 mW Surface Mount SOT-23-3 (TO-236); Manufacturer: onsemi; Automotive Qualified: AEC Q101; Combined Parameters: NPN;Uce:30V;Ic:100mA;P:330mW;F:250MHz; PLMStatus: Not Recommended For New Designs; QuickPick: NPN / 330mW / 100mA / 30V / PG-SOT23; Second Source allowed: Yes; Style: Single; Symbol Type: NPN Bipolar Junction Transistor (BJT), Pin 1 Base, 2 Emitter, 3 Collector, 3 Pins; Version Number: V01; Voltage DC (max): na; Application: Switching; Article Number: 421477; Component Kind: Standard; ComponentLink1Description: Vendor WebSite; ComponentLink1URL: https://www.infineon.com/; ComponentLink2Description: Datasheet; ComponentLink2URL: http://webnetprod.muc.infineon.com/PCBPortal_Prod/WorkFlows/AltiumComponent/StandardDocumentation?Versionid=421478; Manufacturer Order Number: BC848CLT1G; Package Description: PG-SOT23; PackageReference: PG-SOT23; Part Type: Transistor Bipolar; ROHS Compliant: Y; Supplier 1: Farnell; Supplier 2: DigiKey; Supplier 3: Mouser; Supplier Part Number 2: BC848CLT1GOSCT-ND; Temperature max: 150; Temperature Range: Military; Type: NPN; Value: BC848CLT1G; Library Name: EvalKit_AURIX_TC4x7_Lite_V1.SCHLIB
R48 = 632340: 0 Ohms Jumper Chip Resistor Wide 1812 (4532 Metric), 1218 Automotive AEC-Q200 Thick Film; Manufacturer: Vishay; Manufacturer Order Number: RCL12180000Z0EK; Multiple Parts: No; Alternate View: Yes; Automotive Qualified: AEC Q200; Combined Parameters: TCR:-;Tol.:-;Vdc:200V;Idc:-;P:1W; Database Table Name: TPartsAll; PLMStatus: Active; QuickPick: 0R / 1 / 1218; Style: Single; Symbol Type: Resistor; Single; Standard; 2 Pins; Version Number: V01; Voltage DC (max): 200V; Application: Standard; Article Number: 632340; Component Kind: Standard; ComponentLink1Description: Vendor WebSite; ComponentLink1URL: http://www.vishay.com; ComponentLink2Description: Datasheet; ComponentLink2URL: http://webnetprod.muc.infineon.com/PCBPortal_Prod/WorkFlows/AltiumComponent/StandardDocumentation?Versionid=632341; Library Name: PCBLibraryData.SVNDbLib; Package Description: Resistor,Chip;3.15mm L X 4.60mm W X 0.60mm H; PackageReference: 1218; Part Type: Resistor; ROHS Compliant: Y; Second Source allowed: Yes; Supplier 1: Farnell; Supplier 2: DigiKey; Supplier 3: Mouser; Supplier Part Number 2: RCL0.0ACT-ND; Supplier Part Number 3: 71-RCL12180000Z0EK; Technology: Thick Film; Temperature max: 155; Temperature min: -55; Temperature Range: Military; Type: Standard; Value: 0R
R49 R52 = 632340: 0 Ohms Jumper Chip Resistor 1210 (3225 Metric) Automotive AEC-Q200 Thick Film; Manufacturer: Vishay; Manufacturer Order Number: CRCW12100000Z0EA; Multiple Parts: No; Alternate View: Yes; Automotive Qualified: AEC Q200; Combined Parameters: TCR:-;Tol.:-;Vdc:200V;Idc:-;P:1W; Database Table Name: TPartsAll; PLMStatus: Active; QuickPick: 0R / 1 / 1218; Style: Single; Symbol Type: Resistor; Single; Standard; 2 Pins; Version Number: V01; Voltage DC (max): 200V; Application: Standard; Article Number: 632340; Component Kind: Standard; ComponentLink1Description: Vendor WebSite; ComponentLink1URL: http://www.vishay.com; ComponentLink2Description: Datasheet; ComponentLink2URL: http://webnetprod.muc.infineon.com/PCBPortal_Prod/WorkFlows/AltiumComponent/StandardDocumentation?Versionid=632341; Library Name: PCBLibraryData.SVNDbLib; Package Description: Resistor,Chip;3.15mm L X 4.60mm W X 0.60mm H; PackageReference: 1218; Part Type: Resistor; ROHS Compliant: Y; Second Source allowed: Yes; Supplier 1: Farnell; Supplier 2: DigiKey; Supplier 3: Mouser; Supplier Part Number 2: 541-0.0VCT-ND; Technology: Thick Film; Temperature max: 155; Temperature min: -55; Temperature Range: Military; Type: Standard; Value: 0R
R50 R54 = 344072: 2.2 Ohms ±1% 0.333W, 1/3W Chip Resistor 0603 (1608 Metric) Automotive AEC-Q200, Pulse Withstanding Thick Film; Manufacturer: Vishay; Manufacturer Order Number: CRCW06032R20FKEAHP; Multiple Parts: No; Alternate View: Yes; Automotive Qualified: AEC Q200; Combined Parameters: TCR:100ppm/K;Tol.:-1%/+1%;Vdc:75V;Idc:-;P:100mW; ComponentStatus: Released; Database Table Name: TPartsAll; Legacy DeviceID: RES01800837; PLMStatus: Active; QuickPick: 2.2R / 1% / 0.1 / 0603; Style: Single; Symbol Type: Resistor; Single; Standard; 2 Pins; Version Number: V01; Voltage DC (max): 75V; Article Number: 344072; Component Kind: Standard; ComponentLink1Description: Vendor WebSite; ComponentLink1URL: http://www.vishay.com; ComponentLink2Description: Datasheet; ComponentLink2URL: http://webnetprod.muc.infineon.com/PCBPortal_Prod/WorkFlows/AltiumComponent/StandardDocumentation?Versionid=344073; Legacy PartNumber: RES01800837; Library Name: PCBLibraryData.SVNDbLib; PackageReference: 0603; Part Type: Resistor; ROHS Compliant: Y; Second Source allowed: Yes; Supplier 1: Farnell; Supplier 2: DigiKey; Supplier 3: Mouser; Supplier Part Number 2: 541-2.20SCT-ND; Technology: Thick Film; Temperature max: 155; Temperature min: -55; Temperature Range: Military; Tolerance Neg: 1%; Tolerance Pos: 1%; Type: Standard; Value: 2.2R
R51 = 597585_TB: 620 mOhms ±1% 0.125W, 1/8W Chip Resistor 0603 (1608 Metric) Thick Film; Manufacturer: Bourns; Manufacturer Order Number: CRL0603-FW-R620ELF; Alternate View: Yes; Automotive Qualified: No; Combined Parameters: TCR:200ppm/K;Tol.:-1%/+1%;Vdc:-;Idc:-;P:100mW; ComponentStatus: Released; PLMStatus: Active; QuickPick: 0.62R / 1% / 0.100 / 0603; Style: Single; Symbol Type: Resistor; Single; Standard; 2 Pins; Version Number: V01; Application: Standard; Article Number: 597585_TB; Component Kind: Standard; ComponentLink1Description: Vendor WebSite; ComponentLink1URL: http://www.bourns.com; ComponentLink2Description: Datasheet; ComponentLink2URL: http://webnetprod.muc.infineon.com/PCBPortal_Prod/WorkFlows/AltiumComponent/StandardDocumentation?Versionid=597586; Library Name: TriBoard TC4X7 COM.SCHLIB; Multiple Parts: No; Package Description: Resistor,Chip;1.60mm L X 0.8mm W X 0.55mm H; PackageReference: 0603; Part Type: Resistor; ROHS Compliant: Y; Second Source allowed: Yes; Supplier 1: Farnell; Supplier 2: DigiKey; Supplier 3: Mouser; Supplier Part Number 1: 2328099; Supplier Part Number 2: CRL0603-FW-R620ELFCT-ND; Supplier Part Number 3: 652-CRL0603FWR620ELF; Technology: Thick Film; Temperature max: 125; Temperature min: -55; Temperature Range: Military; Tolerance Neg: 1%; Tolerance Pos: 1%; Type: Standard; Value: 0.62R
R53 R58 R61 R64 = 343882: 0 Ohms Jumper Chip Resistor 0603 (1608 Metric) Automotive AEC-Q200, Pulse Withstanding Thick Film; Manufacturer: Vishay; Manufacturer Order Number: CRCW06030000Z0EAHP; Multiple Parts: No; Alternate View: Yes; Automotive Qualified: AEC Q200; Combined Parameters: TCR:-;Tol.:-0R/+0R;Vdc:75V;Idc:2A;P:-; Database Table Name: TPartsAll; Legacy DeviceID: RES01800800; PLMStatus: Active; QuickPick: 0R / 0R / 0603; Style: Single; Symbol Type: Resistor; Single; Standard; 2 Pins; Version Number: V01; Voltage DC (max): 75V; Article Number: 343882; Component Kind: Standard; ComponentLink1Description: Vendor WebSite; ComponentLink1URL: http://www.vishay.com; ComponentLink2Description: Datasheet; ComponentLink2URL: http://webnetprod.muc.infineon.com/PCBPortal_Prod/WorkFlows/AltiumComponent/StandardDocumentation?Versionid=343883; Legacy PartNumber: RES01800800; Library Name: PCBLibraryData.SVNDbLib; PackageReference: 0603; Part Type: Resistor; ROHS Compliant: Y; Second Source allowed: Yes; Supplier 1: Farnell; Supplier 2: DigiKey; Supplier 3: Mouser; Supplier Part Number 2: 541-0.0SBCT-ND; Supplier Part Number 3: 71-CRCW06030000Z0EAH; Technology: Thick Film; Temperature max: 155; Temperature min: -55; Temperature Range: Military; Tolerance Neg: 0R; Tolerance Pos: 0R; Type: Standard; Value: 0R
R55 = 343882: 0 Ohms Jumper Chip Resistor 0603 (1608 Metric) Automotive AEC-Q200, Pulse Withstanding Thick Film; Manufacturer: Vishay; Manufacturer Order Number: CRCW06030000Z0EAHP; Multiple Parts: No; Alternate View: Yes; Automotive Qualified: AEC Q200; Combined Parameters: TCR:-;Tol.:-0R/+0R;Vdc:75V;Idc:2A;P:-; Legacy DeviceID: RES01800800; PLMStatus: Active; QuickPick: 0R / 0R / 0603; Style: Single; Symbol Type: Resistor; Single; Standard; 2 Pins; Version Number: V01; Voltage DC (max): 75V; Article Number: 343882; Component Kind: Standard; ComponentLink1Description: Vendor WebSite; ComponentLink1URL: http://www.vishay.com; ComponentLink2Description: Datasheet; ComponentLink2URL: http://webnetprod.muc.infineon.com/PCBPortal_Prod/WorkFlows/AltiumComponent/StandardDocumentation?Versionid=343883; Legacy PartNumber: RES01800800; Library Name: TriBoard_TC4X7_COM_V20.SCHLIB; PackageReference: 0603; Part Type: Resistor; ROHS Compliant: Y; Second Source allowed: Yes; Supplier 1: Farnell; Supplier 2: DigiKey; Supplier 3: Mouser; Supplier Part Number 2: 541-0.0SBCT-ND; Supplier Part Number 3: 71-CRCW06030000Z0EAH; Technology: Thick Film; Temperature max: 155; Temperature min: -55; Temperature Range: Military; Tolerance Neg: 0R; Tolerance Pos: 0R; Type: Standard; Value: 0R
R56 R57 = 370987: 1 kOhms ±5% 0.063W, 1/16W Chip Resistor 0402 (1005 Metric) Moisture Resistant Thick Film; Manufacturer: Yageo; Manufacturer Component Status: Active; Manufacturer Order Number: RC0402JR-131KL; Alternate View: Yes; Automotive Qualified: No; Combined Parameters: TCR:100ppm/K;Tol.:-5%/+5%;Vdc:50V;Idc:-;P:62.5mW; Legacy DeviceID: RES01806043; QuickPick: 1k / 5% / 0.0625 / 0402; Style: Single; Symbol Type: Resistor; Single; Standard; 2 Pins; Version Number: V01; Voltage DC (max): 50V; Article Number: 370987; Component Kind: Standard; ComponentLink1Description: Vendor WebSite; ComponentLink1URL: http://www.yageo.com/; ComponentLink2Description: Datasheet; ComponentLink2URL: http://webnetprod.muc.infineon.com/PCBPortal_Prod/WorkFlows/AltiumComponent/StandardDocumentation?Versionid=370988; Legacy PartNumber: RES01806043; Multiple Parts: No; PackageReference: 0402; Part Type: Resistor; PLMStatus: Active; ROHS Compliant: Y; Second Source allowed: Yes; Supplier 1: Farnell; Supplier 2: DigiKey; Supplier 3: Mouser; Supplier Part Number 1: 1799315; Supplier Part Number 2: 13-RC0402JR-131KLCT-ND; Supplier Part Number 3: 603-RC0402JR-131KL; Technology: Thick Film; Temperature max: 155; Temperature min: -55; Temperature Range: Military; Tolerance Neg: 5%; Tolerance Pos: 5%; Type: Standard; Value: 1k; Library Name: AURIX_Lite_Kit_V2.0.SCHLIB
R59 = 343932: 1.2 Ohms ±1% 0.1W, 1/10W Chip Resistor 0603 (1608 Metric) Automotive AEC-Q200 Thick Film; Manufacturer: Vishay; Manufacturer Order Number: CRCW06031R20FKEA; Multiple Parts: No; Alternate View: Yes; Automotive Qualified: AEC Q200; Combined Parameters: TCR:100ppm/K;Tol.:-1%/+1%;Vdc:75V;Idc:-;P:100mW; Database Table Name: TPartsAll; Legacy DeviceID: RES01800809; PLMStatus: Active; QuickPick: 1.2R / 1% / 0.1 / 0603; Style: Single; Symbol Type: Resistor; Single; Standard; 2 Pins; Version Number: V01; Voltage DC (max): 75V; Article Number: 343932; Component Kind: Standard; ComponentLink1Description: Vendor WebSite; ComponentLink1URL: http://www.vishay.com; ComponentLink2Description: Datasheet; ComponentLink2URL: http://webnetprod.muc.infineon.com/PCBPortal_Prod/WorkFlows/AltiumComponent/StandardDocumentation?Versionid=343933; Legacy PartNumber: RES01800809; Library Name: PCBLibraryData.SVNDbLib; PackageReference: 0603; Part Type: Resistor; ROHS Compliant: Y; Second Source allowed: Yes; Supplier 1: Farnell; Supplier 2: DigiKey; Supplier 3: Mouser; Supplier Part Number 2: 541-1.20HHCT-ND; Supplier Part Number 3: 71-CRCW06031R20FKEA; Technology: Thick Film; Temperature max: 155; Temperature min: -55; Temperature Range: Military; Tolerance Neg: 1%; Tolerance Pos: 1%; Type: Standard; Value: 1.2R
R60 = 343882: 0 Ohms Jumper Chip Resistor 0603 (1608 Metric) Automotive AEC-Q200, Pulse Withstanding Thick Film; Manufacturer: Vishay; Manufacturer Order Number: CRCW06030000Z0EAHP; Multiple Parts: No; Alternate View: Yes; Automotive Qualified: AEC Q200; Combined Parameters: TCR:-;Tol.:-0R/+0R;Vdc:75V;Idc:2A;P:-; Database Table Name: TPartsAll; Legacy DeviceID: RES01800800; PLMStatus: Active; QuickPick: 0R / 0R / 0603; Style: Single; Symbol Type: Resistor; Single; Standard; 2 Pins; Tolerance Neg: 0R; Value: 0R; Version Number: V01; Voltage DC (max): 75V; Article Number: 343882; Component Kind: Standard; ComponentLink1Description: Vendor WebSite; ComponentLink1URL: http://www.vishay.com; ComponentLink2Description: Datasheet; ComponentLink2URL: http://webnetprod.muc.infineon.com/PCBPortal_Prod/WorkFlows/AltiumComponent/StandardDocumentation?Versionid=343883; Legacy PartNumber: RES01800800; Library Name: PCBLibraryData.SVNDbLib; PackageReference: 0603; Part Type: Resistor; ROHS Compliant: Y; Second Source allowed: Yes; Supplier 1: Farnell; Supplier 2: DigiKey; Supplier 3: Mouser; Supplier Part Number 2: 541-0.0SBCT-ND; Supplier Part Number 3: 71-CRCW06030000Z0EAH; Technology: Thick Film; Temperature max: 155; Temperature min: -55; Temperature Range: Military; Tolerance Pos: 0R; Type: Standard
R62 = 341977: 4.7 kOhms ±1% 0.063W, 1/16W Chip Resistor 0402 (1005 Metric) Automotive AEC-Q200 Thick Film; Manufacturer: Vishay; Manufacturer Order Number: CRCW04024K70FKED; Multiple Parts: No; Alternate View: Yes; Automotive Qualified: AEC Q200; Combined Parameters: TCR:100ppm/K;Tol.:-1%/+1%;Vdc:50V;Idc:-;P:63mW; Database Table Name: TPartsAll; Legacy DeviceID: RES01800419; PLMStatus: Active; QuickPick: 4.7k / 1% / 0.063 / 0402; Style: Single; Symbol Type: Resistor; Single; Standard; 2 Pins; Version Number: V01; Voltage DC (max): 50V; Article Number: 341977; Component Kind: Standard; ComponentLink1Description: Vendor WebSite; ComponentLink1URL: http://www.vishay.com; ComponentLink2Description: Datasheet; ComponentLink2URL: http://webnetprod.muc.infineon.com/PCBPortal_Prod/WorkFlows/AltiumComponent/StandardDocumentation?Versionid=341978; Legacy PartNumber: RES01800419; Library Name: PCBLibraryData.SVNDbLib; PackageReference: 0402; Part Type: Resistor; ROHS Compliant: Y; Second Source allowed: Yes; Supplier 1: Farnell; Supplier 2: DigiKey; Supplier 3: Mouser; Supplier Part Number 1: 2121688; Supplier Part Number 2: 541-4.70KLCT-ND; Technology: Thick Film; Temperature max: 155; Temperature min: -55; Temperature Range: Military; Tolerance Neg: 1%; Tolerance Pos: 1%; Type: Standard; Value: 4.7k
R63 = 342167: 10 kOhms ±1% 0.063W, 1/16W Chip Resistor 0402 (1005 Metric) Automotive AEC-Q200 Thick Film; Manufacturer: Vishay; Manufacturer Order Number: CRCW040210K0FKED; Multiple Parts: No; Alternate View: Yes; Automotive Qualified: AEC Q200; Combined Parameters: TCR:100ppm/K;Tol.:-1%/+1%;Vdc:50V;Idc:-;P:63mW; Database Table Name: TPartsAll; Legacy DeviceID: RES01800457; PLMStatus: Active; QuickPick: 10k / 1% / 0.063 / 0402; Style: Single; Symbol Type: Resistor; Single; Standard; 2 Pins; Version Number: V01; Voltage DC (max): 50V; Article Number: 342167; Component Kind: Standard; ComponentLink1Description: Vendor WebSite; ComponentLink1URL: http://www.vishay.com; ComponentLink2Description: Datasheet; ComponentLink2URL: http://webnetprod.muc.infineon.com/PCBPortal_Prod/WorkFlows/AltiumComponent/StandardDocumentation?Versionid=342168; Legacy PartNumber: RES01800457; Library Name: PCBLibraryData.SVNDbLib; PackageReference: 0402; Part Type: Resistor; ROHS Compliant: Y; Second Source allowed: Yes; Supplier 1: Farnell; Supplier 2: DigiKey; Supplier 3: Mouser; Supplier Part Number 2: 541-10.0KLCT-ND; Supplier Part Number 3: 71-CRCW0402-10K-E3; Technology: Thick Film; Temperature max: 155; Temperature min: -55; Temperature Range: Military; Tolerance Neg: 1%; Tolerance Pos: 1%; Type: Standard; Value: 10k
R65 = 345782: 2.2 kOhms ±1% 0.1W, 1/10W Chip Resistor 0603 (1608 Metric) Automotive AEC-Q200 Thick Film; Manufacturer: Vishay; Manufacturer Order Number: CRCW06032K20FKTA; Multiple Parts: No; Alternate View: Yes; Automotive Qualified: AEC Q200; Combined Parameters: TCR:100ppm/K;Tol.:-1%/+1%;Vdc:75V;Idc:-;P:100mW; Database Table Name: TPartsAll; Legacy DeviceID: RES01801179; PLMStatus: Active; QuickPick: 2.2k / 1% / 0.1 / 0603; Style: Single; Symbol Type: Resistor; Single; Standard; 2 Pins; Version Number: V01; Voltage DC (max): 75V; Article Number: 345782; Component Kind: Standard; ComponentLink1Description: Vendor WebSite; ComponentLink1URL: http://www.vishay.com; ComponentLink2Description: Datasheet; ComponentLink2URL: http://webnetprod.muc.infineon.com/PCBPortal_Prod/WorkFlows/AltiumComponent/StandardDocumentation?Versionid=345783; Legacy PartNumber: RES01801179; Library Name: PCBLibraryData.SVNDbLib; PackageReference: 0603; Part Type: Resistor; ROHS Compliant: Y; Second Source allowed: Yes; Supplier 1: Farnell; Supplier 2: DigiKey; Supplier 3: Mouser; Supplier Part Number 2: 541-CRCW06032K20FKTACT-ND; Supplier Part Number 3: 71-CRCW0603-2.2K; Technology: Thick Film; Temperature max: 155; Temperature min: -55; Temperature Range: Military; Tolerance Neg: 1%; Tolerance Pos: 1%; Type: Standard; Value: 2.2k
R67 = 345982: 4.7 kOhms ±1% 0.1W, 1/10W Chip Resistor 0603 (1608 Metric) Automotive AEC-Q200 Thick Film; Manufacturer: Vishay; Manufacturer Order Number: CRCW06034K70FKEA; Multiple Parts: No; Alternate View: Yes; Automotive Qualified: AEC Q200; Combined Parameters: TCR:100ppm/K;Tol.:-1%/+1%;Vdc:75V;Idc:-;P:100mW; Database Table Name: TPartsAll; Legacy DeviceID: RES01801219; PLMStatus: Active; QuickPick: 4.7k / 1% / 0.1 / 0603; Style: Single; Symbol Type: Resistor; Single; Standard; 2 Pins; Version Number: V01; Voltage DC (max): 75V; Article Number: 345982; Component Kind: Standard; ComponentLink1Description: Vendor WebSite; ComponentLink1URL: http://www.vishay.com; ComponentLink2Description: Datasheet; ComponentLink2URL: http://webnetprod.muc.infineon.com/PCBPortal_Prod/WorkFlows/AltiumComponent/StandardDocumentation?Versionid=345983; Legacy PartNumber: RES01801219; Library Name: PCBLibraryData.SVNDbLib; PackageReference: 0603; Part Type: Resistor; ROHS Compliant: Y; Second Source allowed: Yes; Supplier 1: Farnell; Supplier 2: DigiKey; Supplier 3: Mouser; Supplier Part Number 2: 541-4.70KHCT-ND; Technology: Thick Film; Temperature max: 155; Temperature min: -55; Temperature Range: Military; Tolerance Neg: 1%; Tolerance Pos: 1%; Type: Standard; Value: 4.7k
S3 = 376475: Tactile Switch SPST-NO Top Actuated Surface Mount; Legacy PartNumber: SW0000003; Manufacturer: TE Connectivity ALCOSWITCH Switches; Automotive Qualified: No; Database Table Name: TPartsAll; Legacy DeviceID: TEC_FSM2JSMA; PLMStatus: Active; Second Source allowed: Yes; Style: Not Applicable; Value: FSM2JSMA; Version Number: V01; Voltage DC (max): na; Article Number: 376475; Component Kind: Standard; ComponentLink1Description: Vendor WebSite; ComponentLink1URL: http://www.tycoelectronics.com/; ComponentLink2Description: Datasheet; ComponentLink2URL: http://webnetprod.muc.infineon.com/PCBPortal_Prod/WorkFlows/AltiumComponent/StandardDocumentation?Versionid=376476; Library Name: PCBLibraryData.SVNDbLib; Manufacturer Order Number: FSM2JSMA; Package Description: Switch,Push button ,4.5mm Pitch,Vertical, 6mm L X 6mm W X 5.4mm H body; Part Type: Electro Mechanic; ROHS Compliant: Y; Supplier 1: Farnell; Supplier 2: DigiKey; Supplier 3: Mouser; Supplier Part Number 1: 3801287; Supplier Part Number 2: 450-1130-ND; Supplier Part Number 3: 506-FSM2JSMA; Temperature max: 85; Temperature min: -35; Temperature Range: Military
U12 = 606443_BGA292: TC4xx_BGA292_COM; Manufacturer: Infineon Technologies; Multiple Parts: Yes; Alternate View: No; Combined Parameters: App.:-;-;Vdc min/max:-;Fmax:-;RAM:-;ROM:-;Flash:-; ComponentStatus: Created; Legacy DeviceID: IFX_TC4xx_BGA292_COM; Pins Electrical: 292; PLM Status: Preliminary; PLMStatus: Active; QuickPick: BGA292; Value: IFX_TC4xx_BGA292_COM; Version Number: V01; Voltage DC (max): na; Article Number: 606443_BGA292; Component Kind: Standard; ComponentLink1Description: Vendor WebSite; ComponentLink1URL: https://www.infineon.com/; ComponentLink2Description: Datasheet; Description (long): TC4xx_BGA292_COM; Footprint Ref: FCBGA292_4L; Library Ref: TC4xx_BGA292_COM; Manufacturer Order Number: TC4xx_BGA292_COM; Package Description: BGA, SMD; 0.80 mm Pitch, 292 pin, 17.00 mm L X 17.00 mm W X 1.10 mm H body; Package Reference: BGA292; PackageReference: BGA292; Part Type: Microcontroller; Pins Total: 292; Product Name: IFX_TC4xx_BGA292_COM; Second Source allowed: Yes; Supplier 1: Farnell; Supplier 2: DigiKey; Supplier 3: Mouser; Symbol Type: TC4xx_BGA292_COM; Temperature Range: Military; Vendor WebSite: https://www.infineon.com/; Library Name: AURIX_4x7_Lite_Kit.SCHLIB
Y3 = 700886: 25 MHz ±20ppm Crystal 12pF 40 Ohms 4-SMD, No Lead; Manufacturer: ECS Inc; Manufacturer Order Number: ECS-250-12-33Q-JES-TR; Automotive Qualified: AEC Q200; Combined Parameters: Standard Standard Crystal;Tol.:-20%/+20%; ComponentStatus: Released; Database Table Name: TPartsAll; PLMStatus: Active; QuickPick: 25000000 / Crystal; Second Source allowed: Yes; Style: Standard; Symbol type: Standard Crystal Symbol, 4 Pins; Tolerance Neg: 20%; Version Number: V00; Voltage DC (max): na; Application: Standard; Article Number: 700886; Component Kind: Standard; ComponentLink1Description: Vendor WebSite; ComponentLink1URL: http://www.ecsxtal.com/; ComponentLink2Description: Datasheet; ComponentLink2URL: http://webnetprod.muc.infineon.com/PCBPortal_Prod/WorkFlows/AltiumComponent/StandardDocumentation?Versionid=700887; Library Name: PCBLibraryData.SVNDbLib; Package Description: Crystal, SMD; 2.5mm W X 3.2mm L X 0.8mm H body; PackageReference: 3225; Part Type: Clock; ROHS Compliant: Y; Supplier 1: Farnell; Supplier 2: DigiKey; Supplier 3: Mouser; Supplier Part Number 1: 3649701; Supplier Part Number 2: XC2151CT-ND; Supplier Part Number 3: 520-250-12-33Q-JEST; Temperature max: 125; Temperature min: -40; Temperature Range: Automotive; Tolerance Pos: 20%; Type: Crystal; Value: 25MHz
